# Altium schematic sheet Interfacing.SchDoc
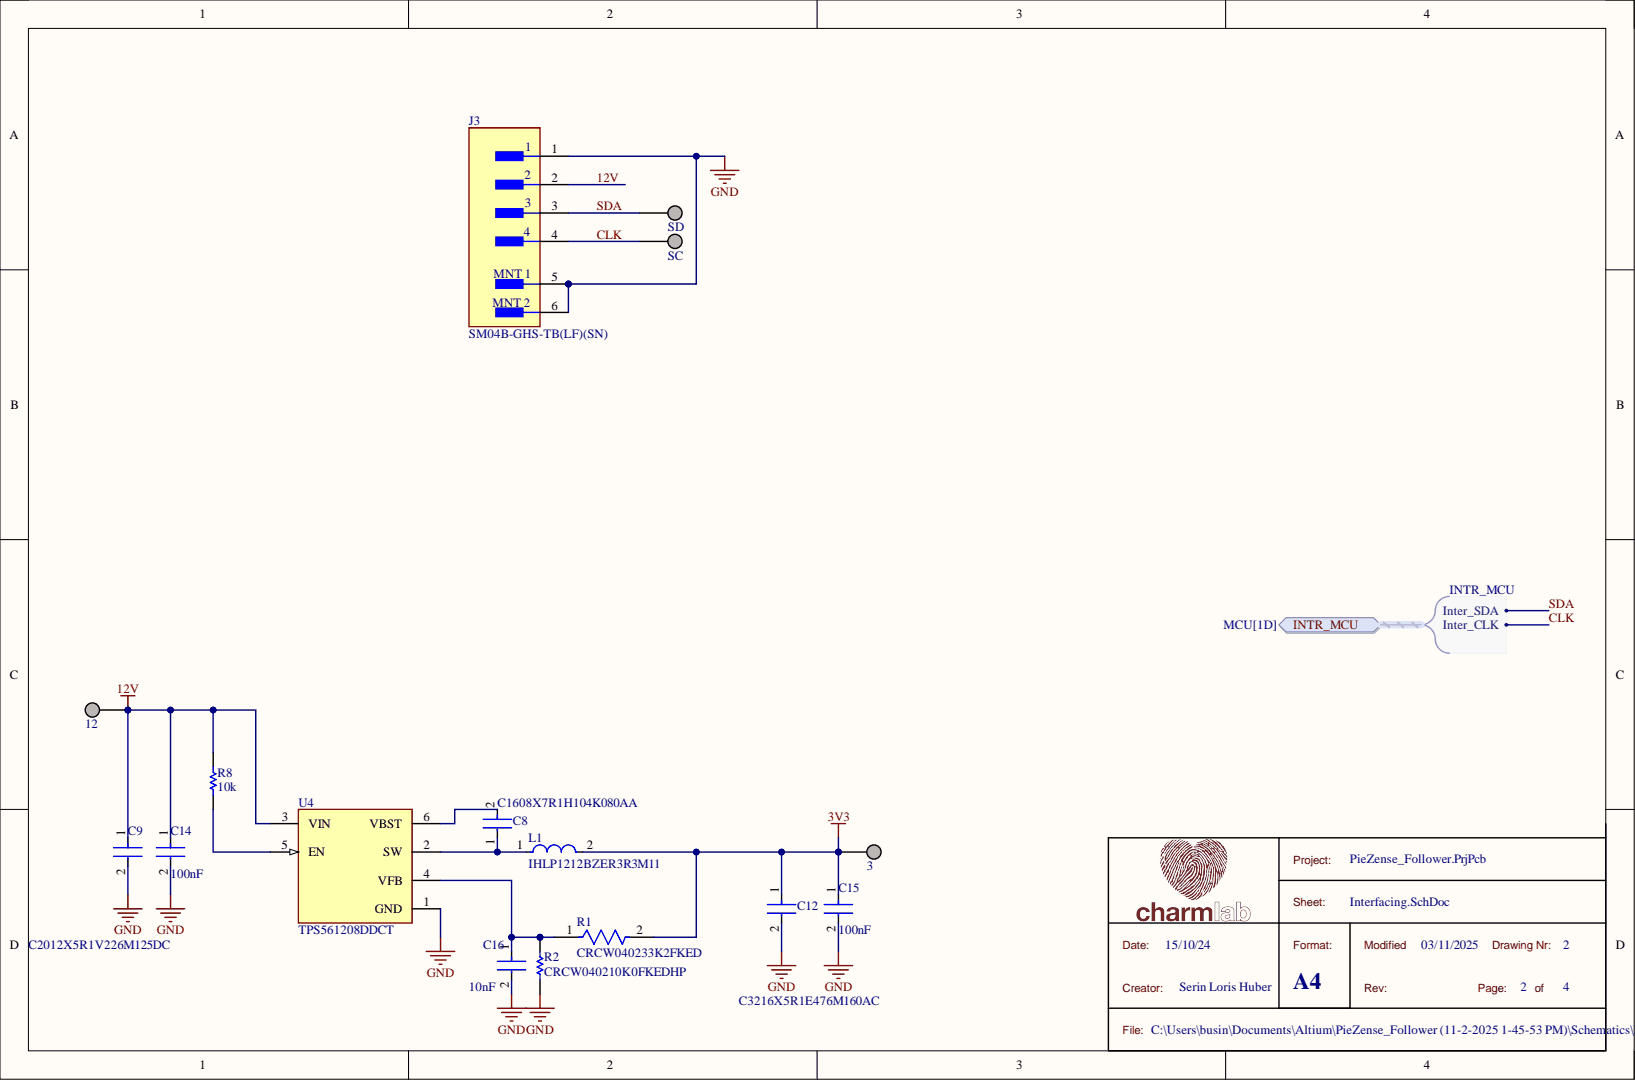
[diagram p0: Interfacing.SchDoc]

## Parts
3  Test point  [TEST_POINT]  (value not drawn)  (no BOM)
12  Test point  [TEST_POINT]  (value not drawn)  (no BOM)
C8  C1608X7R1H104K080AA  [FP-C1608-080-0_1-IPC_B]
C9  C2012X5R1V226M125DC  [FP-C2012-125-0_2-MFG]
C12  C3216X5R1E476M160AC  [FP-C3216-160-0_2-MFG]
C14  100nF  [FP-GRM155-0_05-MFG]
C15  100nF  [FP-GRM155-0_05-MFG]
C16  10nF  [FP-GRM155-0_05-MFG]
J3  SM04B-GHS-TB(LF)(SN)  [FP-SM04B-GHS-TB_LF_SN-MFG]
L1  IHLP1212BZER3R3M11  [FP-IHLP-1212BZ-11-MFG]
R1  CRCW040233K2FKED  [Vishay_CRCW0402-e3_MFG]
R2  CRCW040210K0FKEDHP  [RESC1005X40X25ML05T10]
R8  10k  [RESC1005X40X25ML05T10]
SC  Test point  [TEST_POINT]  (value not drawn)  (no BOM)
SD  Test point  [TEST_POINT]  (value not drawn)  (no BOM)
U4  TPS561208DDCT  [FP-DDC0006A-MFG]

## Ports
INTR_MCU, INTR_MCU.Inter_CLK, INTR_MCU.Inter_SDA

## Nets
3V3: 3.1 C12.1 C15.1 L1.2 R1.2
GND: C9.2 C12.2 C14.2 C15.2 C16.2 J3.1 J3.5 J3.6 R2.2 U4.1(GND)
12V: 12.1 C9.1 C14.1 J3.2 R8.2 U4.3(VIN)
CLK: J3.4 SC.1 ; port INTR_MCU
SDA: J3.3 SD.1 ; port INTR_MCU
NetC8_1: C8.1 L1.1 U4.2(SW)
NetC8_2: C8.2 U4.6(VBST)
NetC16_1: C16.1 R1.1 R2.1 U4.4(VFB)
NetR8_1: R8.1 U4.5(EN)

## Library data of the parts
C8 = CMP-2000-04982-2: Multilayer Ceramic Capacitors 100nF ±10% 50V X7R SMD 0603; Capacitance: 100nF; Case Code (Imperial): 0603; Case Code (Metric): 1608; Case/Package: 0603; Component Kind: Standard; Construction: Flat; Depth: 0.8mm; Dielectric: X7R; Dielectric Material: Ceramic; Height: 0.8mm; Lead Free: Lead Free; Length: 1.6mm; Library Name: Altium Content Vault; Max Operating Temperature: 125°C; Min Operating Temperature: -55°C; Mount: Surface Mount; Number of Pins: 2; Package Quantity: 1; Packaging: Tape and Reel; Radiation Hardening: No; REACH SVHC: No SVHC; Resistor Type: Ceramic; RoHS Compliant: Yes; Series: C; Termination: SMD/SMT; Thickness: 0.037inch; Tolerance: 10%; Voltage: 50V; Voltage Rating: 50V; Voltage Rating (DC): 50V; Weight: 7.1E-05oz; Width: 0.031inch
C9 = CMP-2000-06038-3: Multilayer Ceramic Capacitors 22μF ±20% 35V X5R SMD 0805; Capacitance: 22uF; Case Code (Imperial): 0805; Case Code (Metric): 2012; Case/Package: 0805; Component Kind: Standard; Dielectric: X5R; Dielectric Material: Ceramic; Height: 1.25mm; Lead Free: Lead Free; Length: 0.079inch; Library Name: Altium Content Vault; Max Operating Temperature: 85°C; Min Operating Temperature: -55°C; Mount: Surface Mount; Package Quantity: 2000; Packaging: Tape and Reel; REACH SVHC: No SVHC; RoHS Compliant: Yes; Series: C; Termination: SMD/SMT; Thickness: 0.057inch; Tolerance: 20%; Voltage Rating: 35V; Voltage Rating (DC): 35V; Weight: 0.000194oz; Width: 0.049inch
C12 = CMP-2000-05397-3: Multilayer Ceramic Capacitors 47uF ±20% 25V X5R SMD 1206; Capacitance: 47uF; Case Code (Imperial): 1206; Case Code (Metric): 3216; Case/Package: 1206; Component Kind: Standard; Depth: 1.6mm; Dielectric: X5R; Dielectric Material: Ceramic; Height: 1.6mm; Lead Free: Lead Free; Length: 0.126inch; Library Name: Altium Content Vault; Max Operating Temperature: 85°C; Min Operating Temperature: -55°C; Mount: Surface Mount; Package Quantity: 1; Packaging: Tape and Reel; REACH SVHC: No SVHC; Resistor Type: Ceramic; RoHS Compliant: Yes; Series: C; Termination: SMD/SMT; Thickness: 0.071inch; Tolerance: 20%; Voltage: 25V; Voltage Rating: 25V; Voltage Rating (DC): 25V; Weight: 0.000571oz; Width: 0.063inch
C14 C15 = CMP-2008-04108-2: Chip Multilayer Ceramic Capacitors for General Purpose, 0402, 0.10uF, X5R, 15%, 10%, 50V; Capacitance: 100nF; Case Code (Imperial): 0402; Case Code (Metric): 1005; Case/Package: 0402; Component Kind: Standard; Depth: 0.5mm; Dielectric: X5R; Height: 0.5mm; Lead Free: Lead Free; Length: 1mm; Library Name: Altium Content Vault; Max Operating Temperature: 85°C; Min Operating Temperature: -55°C; Mount: Surface Mount; Packaging: Tape and Reel; REACH SVHC: No SVHC; Thickness: 0.022inch; Tolerance: 10%; Voltage: 50V; Voltage Rating: 50V; Voltage Rating (DC): 50V; Width: 0.02inch
C16 = CMP-2008-04108-2: Chip Multilayer Ceramic Capacitors for General Purpose, 0402, 0.10uF, X5R, 15%, 10%, 50V; Capacitance: 100nF; Case Code (Imperial): 0402; Case Code (Metric): 1005; Case/Package: 0402; Component Kind: Standard; Depth: 0.5mm; Dielectric: X5R; Height: 0.5mm; Lead Free: Lead Free; Length: 1mm; Library Name: Altium Content Vault; Max Operating Temperature: 85°C; Min Operating Temperature: -55°C; Mount: Surface Mount; Packaging: Tape and Reel; REACH SVHC: No SVHC; Thickness: 0.022inch; Tolerance: 10%; Voltage: 50V; Voltage Rating: 50V; Voltage Rating (DC): 50V; Width: 0.02inch
J3 = CMP-17439-000411-1: CONN HEADER SMD R/A 4POS 1.25MM; Current Rating: 1A; Number of Contacts: 4; Voltage Rating: 50V; Component Kind: Standard; Contact Material: Bronze; Contact Plating: Tin; Housing Color: Natural; Housing Material: Nylon; Lead Free: Lead Free; Library Name: Altium Content Vault; Mount: Surface Mount; Number of Positions: 4; Number of Rows: 1; Orientation: Right Angle; Packaging: Cut Tape; Pitch: 1.25mm; REACH SVHC: No SVHC; Series: GH; Termination: Solder
L1 = CMP-02424-000857-1: FIXED IND 3.3UH 2.7A 61 MOHM SMD; Core Material: Ferrite; DC Resistance (DCR): 61mR; Inductance Tolerance: 20%; Saturation Current: 3.3A; Self Resonant Frequency: 45MHz; Shielding: Shielded; Test Frequency: 100kHz; Case Code (Imperial): 1212; Case Code (Metric): 3630; Case/Package: 1212; Component Kind: Standard; Contact Plating: Tin; Current Rating: 2.7A; Depth: 3.2mm; Height: 2mm; Height - Seated (Max): 0.079inch; Inductance: 3.3uH; Lead Free: Lead Free; Length: 0.144inch; Library Name: Altium Content Vault; Max DC Current: 2.7A; Max Operating Temperature: 125°C; Military Standard: Not; Min Operating Temperature: -55°C; Mount: Surface Mount; Number of Pins: 2; Package Quantity: 3000; Packaging: Tape and Reel; Radiation Hardening: No; RMS Current (Irms): 2.7A; Series: IHLP-1212BZ-11; Termination: SMD/SMT; Tolerance: 20%; Width: 0.118inch
R1 = CMP-2002-08529-2: NexarPartId: 39869749; Max Power Dissipation: 63mW; Resistance: 33.2kΩ; Temperature Coefficient: 100ppm/°C; Tolerance: 1%; Voltage Rating: 50V; Case Code (Imperial): 0402; Case Code (Metric): 1005; Case/Package: 0402; Component Kind: Standard; Composition: Thick Film; Contact Plating: Tin; Depth: 500µm; Features: Automotive AEC-Q200; Height: 350µm; Lead Free: Lead Free; Length: 1mm; Library Name: Altium Content Vault; Max Operating Temperature: 155°C; Military Standard: Not; Min Operating Temperature: -55°C; Mount: Surface Mount; Number of Pins: 2; Number of Terminations: 2; Packaging: Cut Tape; Power Rating: 63mW; Radiation Hardening: No; REACH SVHC: Unknown; RoHS: Compliant; Schedule B: 8533210045, 8533210045; Termination: SMD/SMT; Voltage Rating (DC): 50V; Weight: 652.039032µg; Width: 500µm
R2 = CMP-2002-03043-1: Manufacturer: Vishay Dale; Component Kind: Standard; Library Name: Altium Content Vault; Part Number: CRCW040210K0FKEDHP
R8 = CMP-2002-03043-1: Manufacturer: Vishay Dale; Part Number: CRCW040210K0FKEDHP; Component Kind: Standard; Library Name: Altium Content Vault
U4 = CMP-04918-000406-1: IC REG BUCK ADJ 1A TSOT23-6; Max Input Voltage: 17V; Max Output Voltage: 7V; Min Input Voltage: 4.5V; Min Output Voltage: 760mV; Number of Outputs: 1; Output Configuration: Positive; Output Type: Adjustable; Switching Frequency: 580kHz; Synchronous Rectifier: Yes; Case/Package: SOT-23-6; Component Kind: Standard; Library Name: Altium Content Vault; Max Operating Temperature: 85°C; Min Operating Temperature: -40°C; Mount: Surface Mount; Output Current: 1A; Packaging: Cut Tape; RoHS Compliant: Yes
3 12 SC SD = Test point: Library Name: schuluka.SCHLIB
